# Altium schematic sheet 5V_Buck.SchDoc
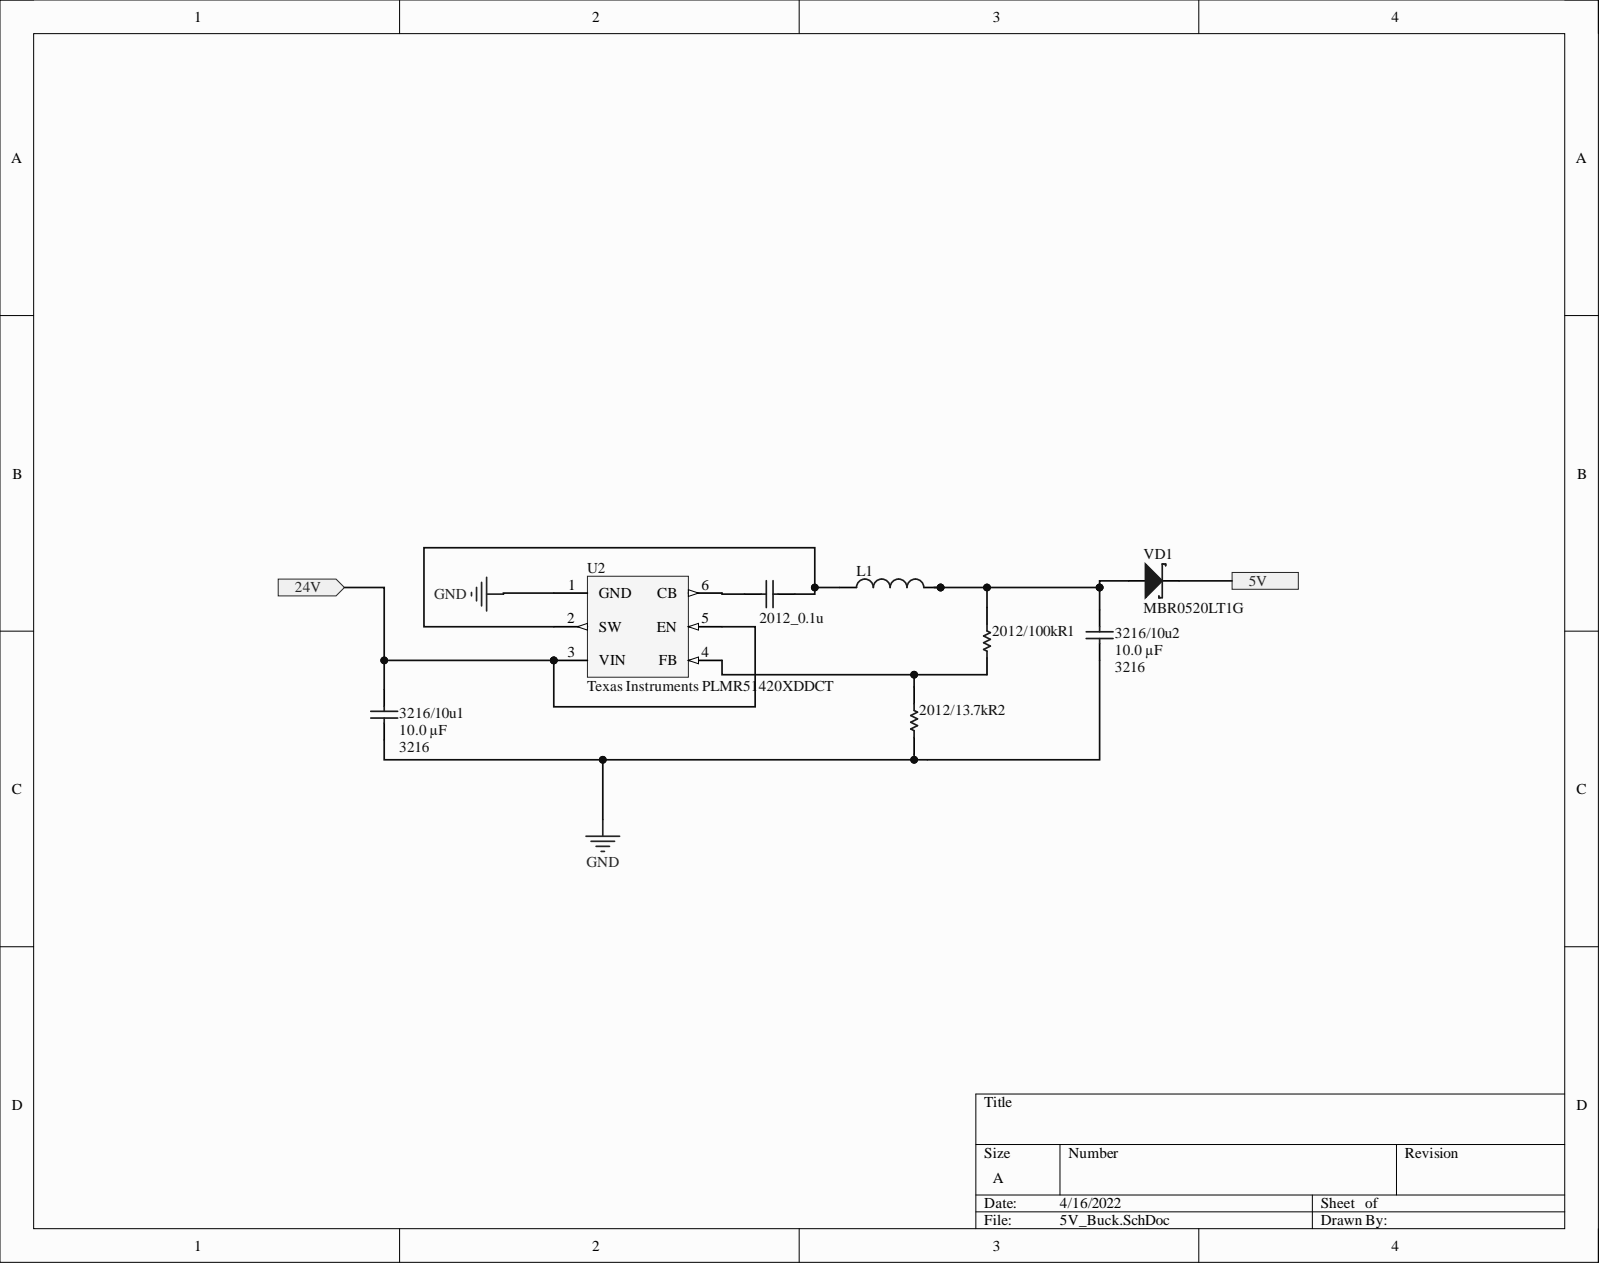
[diagram p0: 5V_Buck.SchDoc]

## Parts
2012/13.7kR2  AGEO RC0805JR-072KL  [PCB-87ovetinf55hg9zqhv8p-1]  (value not drawn)
2012/100kR1  AGEO RC0805JR-072KL  [PCB-87ovetinf55hg9zqhv8p-1]  (value not drawn)
2012_0.1u  KEMET C0805C104K5RACTU  [PCB-m0kg6dhldpqif5mvr6zw-1]  (value not drawn)
3216/10u1  Size: 3216  [High Density]  (hidden comment: Cap)
3216/10u2  Size: 3216  [High Density]  (hidden comment: Cap)
L1  Samsung CIGW252010EH4R7MNE  [PCB-07q76at8oyn5vj86m7fq-1]  (value not drawn)
U2  Texas Instruments PLMR51420XDDCT  Manufacturer Part Number: PLMR51420XDDCT  [PCB-1484289-1]
VD1  MBR0520LT1G  [SOD-123_B]

## Ports
5V, 24V

## Nets
GND: 2012/13.7kR2.1 3216/10u1.2 3216/10u2.2 U2.1(GND)
5V: VD1.1 ; port 5V
24V: 3216/10u1.1 U2.3(VIN) U2.5(EN) ; port 24V
Net2012/13.7kR2_2: 2012/13.7kR2.2 2012/100kR1.1 U2.4(FB)
Net2012/100kR1_2: 2012/100kR1.2 3216/10u2.1 L1.2 VD1.2
Net2012_0.1u_1: 2012_0.1u.1 L1.1 U2.2(SW)
Net2012_0.1u_2: 2012_0.1u.2 U2.6(CB)

## Library data of the parts
2012/13.7kR2 2012/100kR1 = CMP-1854011-4: RC Series 0805 0.125 W 2 kOhms 5% ±100 ppm/°C SMT Thick Film Chip Resistor; Case/Package (SI): 2012; Lead-Free Status: Lead Free; Power Rating: 0.125 W; Resistance: 2000.0 Ω; Voltage Rating (DC): 150.0 V; Case/Package: 0805; Component Kind: Standard; Library Name: My Personal Space; Manufacturer Part Number: RC0805JR-072KL; Mounting Style: Surface Mount; Number of Pins: 2; Octopart Url: http://octopart.com/rc0805jr-072kl-yageo-1251295; OctopartID: 57629e7b65db88a5; Resistance Tolerance: ±5%; RoHS: Compliant; Seller Landing  Url: https://octopart-clicks.com/click/track?country=US&ak=8157f166&sig=0739e0e&sid=2402&ppid=1251295&vpid=121062726&ct=offers; Size-Height: 0.0005 m; Size-Length: 0.002 m; Size-Width: 0.00125 m
2012_0.1u = CMP-8264279-17: Cap Ceramic 0.1uF 50V X7R 10% SMD 0805 125C Plastic T/R; Capacitance: 100 nF; Case/Package (SI): 2012; Lead-Free Status: R; Voltage Rating (DC): 50.0 V; Capacitance Tolerance: ±10%; Case/Package: 0805; Component Kind: Standard; Dielectric Characteristic: X7R; Dielectric Material: Ceramic; Library Name: My Personal Space; Lifecycle Status: Not Listed by Manufacturer; Manufacturer Part Number: C0805C104K5RACTU; Mounting Style: Surface Mount; Number of Pins: 2; Octopart URL: https://octopart.com/c0805c104k5ractu-kemet-143926; OctopartID: e3f12af9f4a13c36; Operating Temperature: -55.0 °C to 125 °C; Packaging: Cut Tape (CT), Reel, Tape & Reel (TR); REACH SVHC Compliance: No SVHC; RoHS: Compliant; Size-Height: 900 µm; Size-Length: 2.00 mm; Size-Width: 1.25 mm
3216/10u1 3216/10u2 = CMP-bac072e4006c6507-6: CL31 Series 10 uF 50 V ±10 % Tolerance X5R SMT Multilayer Ceramic Capacitor; Manufacturer Part Number: CL31A106KBHNNNE; Capacitance: 10.0 µF; Lead-Free Status: Lead Free; Voltage Rating (DC): 50.0 V; Component Kind: Standard; Library Name: CommunityVault; Mounting Style: Surface Mount; OctopartID: bac072e4006c6507
L1 = CMP-b2fc7f28c15a6d3b-1: Fixed Ind 4.7UH 1.4A 150MOHM; Inductance: 4.7µH; Rated DC current (Isat): 1.9A; Component Kind: Standard; DC Resistance Max: 150 mOhm; Library Name: My Personal Space; Manufacturer Part Number: CIGW252010EH4R7MNE; Octopart URL: https://octopart.com/cigw252010eh4r7mne-samsung-81680064; OctopartID: b2fc7f28c15a6d3b; Rated DC current (Irms): 1.4A; Thickness: 1mm
U2 = CMP-ae6e6037501671a9-1: SIMPLE SWITCHER® power converter 4.5-V to 36-V, 2-A, synchronous buck with 40-µA IQ 6-SOT-23-THIN -40 to 125; Component Kind: Standard; Library Name: My Personal Space; Lifecycle Status: Not Listed by Manufacturer; Octopart Url: https://octopart.com/plmr51420xddct-texas+instruments-122936094; OctopartID: ae6e6037501671a9
VD1 = CMP-3786467-11: Rectifier, Schottky Power; Plastic Sod-123; 0.5 a @ +90c If; +125; 20v; 1000; Manufacturer Part Number: ‎SBR80520LT1G; Current Rating: 0.5 A; Forward Voltage: 0.385 V; Lead-Free Status: Lead Free; Polarity: Standard; Voltage Rating (DC): 20.0 V; Case/Package: SOD-123; Component Kind: Standard; Library Name: CommunityVault; Lifecycle Status: Active; Mounting Style: Surface Mount; Number of Pins: 2; Octopart Url: http://octopart.com/mbr0520lt1g-on+semiconductor-2933; OctopartID: 81934899af805e54; REACH SVHC Compliance: No SVHC; RoHS: Compliant; Seller Landing  Url: https://octopart-clicks.com/click/track?country=US&ak=8157f166&sig=02b8313&sid=5489&ppid=2933&vpid=120602749&ct=offers
